# Altium schematic sheet NAND_Flash.SchDoc
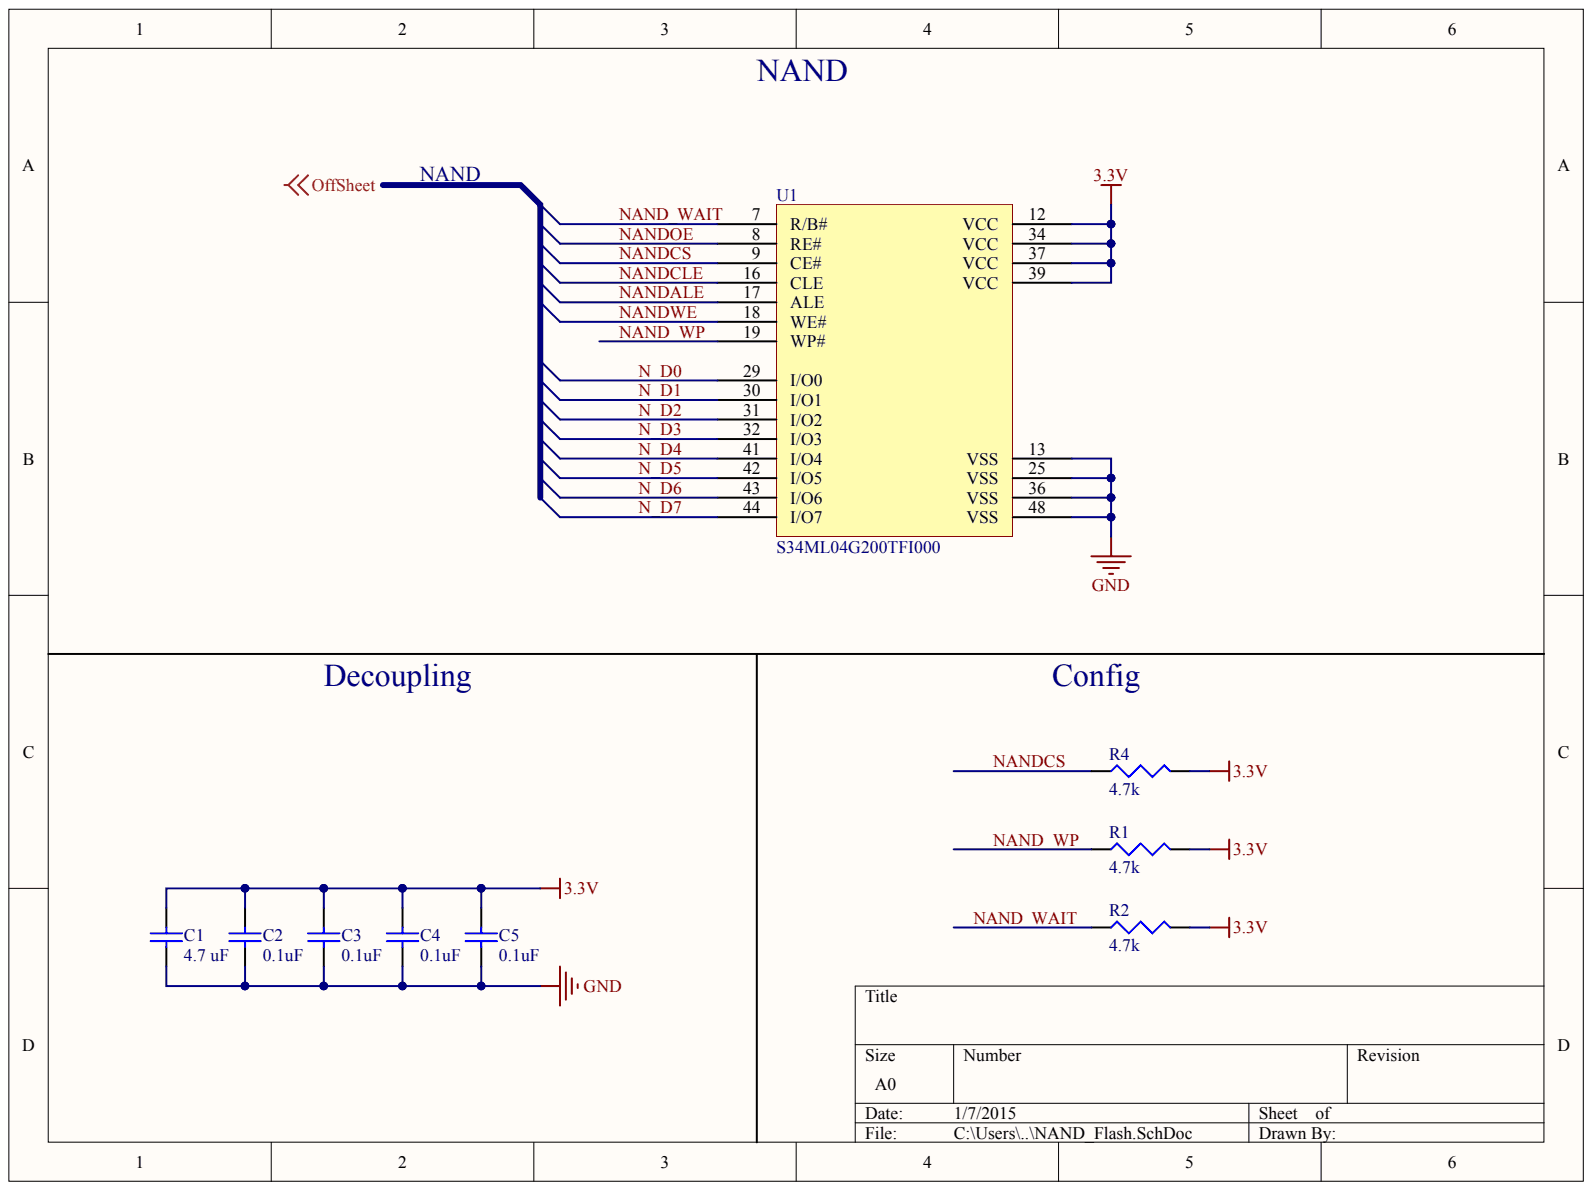
[diagram p0: NAND_Flash.SchDoc]

## Parts
C1  value 4.7 uF  [1608[0603]]  (hidden comment: CL10B475KQ8NQNC)
C2  value 0.1uF  [0402]  (hidden comment: CL05B104KQ5NNNC)
C3  value 0.1uF  [0402]  (hidden comment: CL05B104KQ5NNNC)
C4  value 0.1uF  [0402]  (hidden comment: CL05B104KQ5NNNC)
C5  value 0.1uF  [0402]  (hidden comment: CL05B104KQ5NNNC)
R1  value 4.7k  [J1-0603]  (hidden comment: RMCF0603JT4K70)
R2  value 4.7k  [J1-0603]  (hidden comment: RMCF0603JT4K70)
R4  value 4.7k  [J1-0603]  (hidden comment: RMCF0603JT4K70)
U1  S34ML04G200TFI000  [TSSOP50P2000X120-48N]

## Nets
3.3V: C1.2 C2.2 C3.2 C4.2 C5.2 R1.2 R2.2 R4.2 U1.12(VCC) U1.34(VCC) U1.37(VCC) U1.39(VCC)
GND: C1.1 C2.1 C3.1 C4.1 C5.1 U1.13(VSS) U1.25(VSS) U1.36(VSS) U1.48(VSS)
N_D0: U1.29(I/O0)
N_D1: U1.30(I/O1)
N_D2: U1.31(I/O2)
N_D3: U1.32(I/O3)
N_D4: U1.41(I/O4)
N_D5: U1.42(I/O5)
N_D6: U1.43(I/O6)
N_D7: U1.44(I/O7)
NAND_WAIT: R2.1 U1.7(R/B#)
NAND_WP: R1.1 U1.19(WP#)
NANDALE: U1.17(ALE)
NANDCLE: U1.16(CLE)
NANDCS: R4.1 U1.9(CE#)
NANDOE: U1.8(RE#)
NANDWE: U1.18(WE#)
N00076:
OFFSHEET:

## Library data of the parts
C1 = CL10B475KQ8NQNC: CAP CER 4.7UF 6.3V 10% X7R 0603; Component Kind: Standard; LatestRevisionDate: 29-May-2009; LatestRevisionNote: IPC-7351 Footprint Added.; PackageDescription: Chip Capacitor; PackageReference: C1206; Published: 8-Jun-2000; Signal Integrity: Cap Semi; Simulation: CAP; Supplier 1: Digi-Key; Supplier Part Number 1: 1276-2087-1-ND; VALUE: 4.7 uF; Library Name: Linux_Board_SCHEM_LIB.SchLib; Publisher: Altium Limited
C2-C5 = CL05B104KQ5NNNC: Cap Ceramic 0.1uF 6.3V X7R 10% SMD 0402; Component Kind: Standard; LatestRevisionDate: 29-May-2009; LatestRevisionNote: IPC-7351 Footprint Added.; PackageDescription: Chip Capacitor; PackageReference: C1206; Published: 8-Jun-2000; Signal Integrity: Cap Semi; Simulation: CAP; Supplier 1: Arrow; Supplier 2: Digi-Key; Supplier Part Number 1: c0Ft5huTF1PnwzXLA59QPYxRs3E3aghew1LSAii7cAMZVywxIBNyoAQstvnyfZZ0; Supplier Part Number 2: 1276-1511-1-ND; Library Name: Linux_Board_SCHEM_LIB.SchLib; Publisher: Altium Limited
R1 R2 R4 = RMCF0603JT4K70: RES 4.7K OHM 1/10W 5% 0603; Component Kind: Standard; LatestRevisionDate: 29-May-2009; LatestRevisionNote: IPC-7351 Footprint Added.; PackageDescription: Chip Resistor; PackageReference: J1-0603; Published: 8-Jun-2000; Signal Integrity: Res; Simulation: RESISTOR; Supplier 1: Digi-Key; Supplier 2: Arrow; Supplier Part Number 1: RMCF0603JT4K70CT-ND; Supplier Part Number 2: QpvnIvxQrb47WQ_XjFL3E5TkNE8-LA98qHlgf5QoEq8; Library Name: Linux_Board_SCHEM_LIB.SchLib; Publisher: Altium Limited
U1 = S34ML04G200: IC FLASH 4GBIT 25NS 48TSOP 8bit IO; Component Kind: Standard; Ibis Model: S34ML04G2; Signal Integrity: Guessed Model; Supplier 1: Digi-Key; Supplier 2: Arrow; Supplier Part Number 1: 1274-1044-ND; Supplier Part Number 2: 8WE28XTYbQmAWHoJD1RrkgOUJoc9VKyhs-6KJB9zAtpKN1X50Mmf9yIQquWxDWMO; Library Name: Linux_Board_SCHEM_LIB.SchLib
